# Altium schematic sheet LogicCircuits.SchDoc
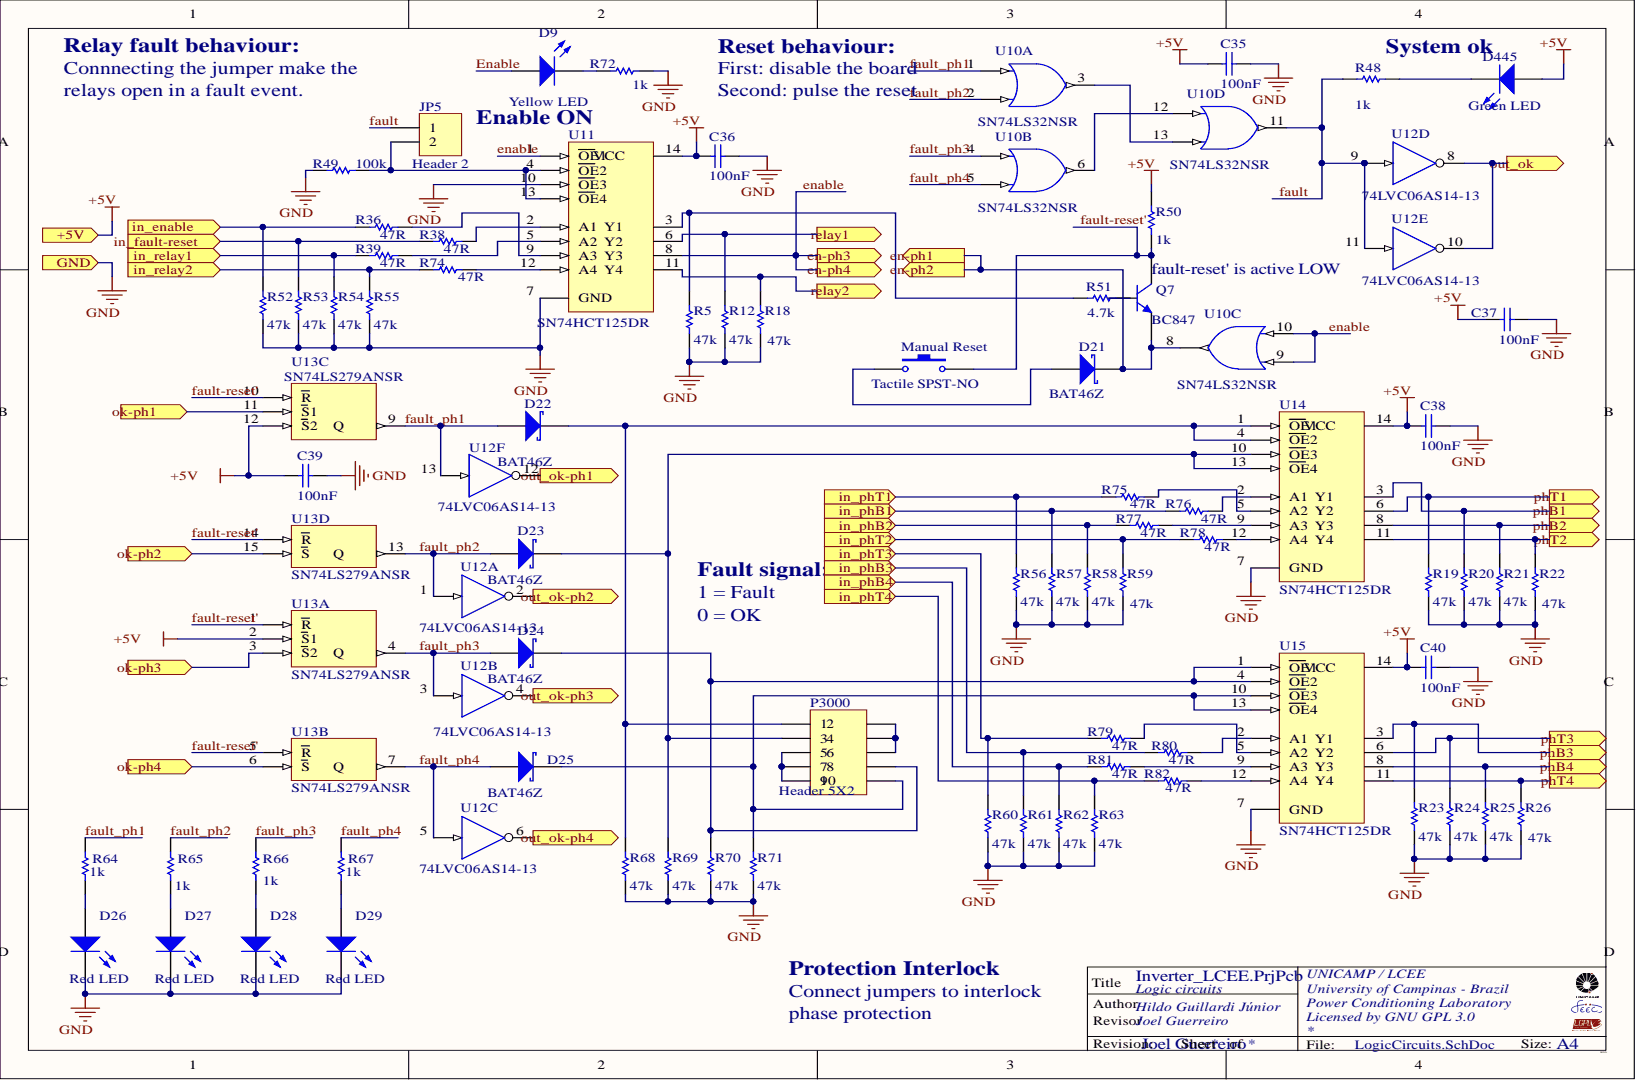
[diagram p0: LogicCircuits.SchDoc]

## Parts
C35  value 100nF  [0805_W]
C36  value 100nF  [0805_W]
C37  value 100nF  [0805_W]
C38  value 100nF  [0805_W]
C39  value 100nF  [0805_W]
C40  value 100nF  [0805_W]
D9  value Yellow LED  [LED_0805]
D21  value BAT46Z  [SOD-123]
D22  value BAT46Z  [SOD-123]
D23  value BAT46Z  [SOD-123]
D24  value BAT46Z  [SOD-123]
D25  value BAT46Z  [SOD-123]
D26  value Red LED  [LED_0805]
D27  value Red LED  [LED_0805]
D28  value Red LED  [LED_0805]
D29  value Red LED  [LED_0805]
D445  value Green LED  [LED_0805]
JP5  value Header 2  [HDR1X2]
Manual_Reset  value Tactile SPST-NO  [Tactile SPST-NO]
P3000  value Header 5X2  [HDR2X5]
Q7  value BC847  [SOT-23-TT3_N]
R5  value 47k  [0805_W]
R12  value 47k  [0805_W]
R18  value 47k  [0805_W]
R19  value 47k  [0805_W]
R20  value 47k  [0805_W]
R21  value 47k  [0805_W]
R22  value 47k  [0805_W]
R23  value 47k  [0805_W]
R24  value 47k  [0805_W]
R25  value 47k  [0805_W]
R26  value 47k  [0805_W]
R36  value 47R  [0805_W]
R38  value 47R  [0805_W]
R39  value 47R  [0805_W]
R48  value 1k  [0805_W]
R49  value 100k  [0805_W]
R50  value 1k  [0805_W]
R51  value 4.7k  [0805_W]
R52  value 47k  [0805_W]
R53  value 47k  [0805_W]
R54  value 47k  [0805_W]
R55  value 47k  [0805_W]
R56  value 47k  [0805_W]
R57  value 47k  [0805_W]
R58  value 47k  [0805_W]
R59  value 47k  [0805_W]
R60  value 47k  [0805_W]
R61  value 47k  [0805_W]
R62  value 47k  [0805_W]
R63  value 47k  [0805_W]
R64  value 1k  [0805_W]
R65  value 1k  [0805_W]
R66  value 1k  [0805_W]
R67  value 1k  [0805_W]
R68  value 47k  [0805_W]
R69  value 47k  [0805_W]
R70  value 47k  [0805_W]
R71  value 47k  [0805_W]
R72  value 1k  [0805_W]
R74  value 47R  [0805_W]
R75  value 47R  [0805_W]
R76  value 47R  [0805_W]
R77  value 47R  [0805_W]
R78  value 47R  [0805_W]
R79  value 47R  [0805_W]
R80  value 47R  [0805_W]
R81  value 47R  [0805_W]
R82  value 47R  [0805_W]
U10  value SN74LS32NSR  [SO14-Wide]  (parts A,B,C,D)
U11  value SN74HCT125DR  [SO14-Wide]
U12  value 74LVC06AS14-13  [SOIC-14N]  (parts A,B,C,D,E,F)
U13  value SN74LS279ANSR  (parts A,B,C,D)
U14  value SN74HCT125DR  [SO14-Wide]
U15  value SN74HCT125DR  [SO14-Wide]

## Ports
+5V, EN-PH1, EN-PH2, EN-PH3, EN-PH4, GND, IN_ENABLE, IN_FAULT-RESET, IN_PHB1, IN_PHB2, IN_PHB3, IN_PHB4, IN_PHT1, IN_PHT2, IN_PHT3, IN_PHT4, IN_RELAY1, IN_RELAY2, OK-PH1, OK-PH2, OK-PH3, OK-PH4, OUT_OK, OUT_OK-PH1, OUT_OK-PH2, OUT_OK-PH3, OUT_OK-PH4, PHB1, PHB2, PHB3, PHB4, PHT1, PHT2, PHT3, PHT4, RELAY1, RELAY2

## Nets
+5V: C35.1 C36.1 C37.1 C38.1 C39.1 C40.1 D445.1 R50.2 U10.14 U11.14 U12.14 U13.2(S1) U13.12(S2) U13.16 U14.14 U15.14 ; port +5V
ENABLE: D9.1 U10.9 U10.10 U11.1(OE1) U11.8 ; port EN-PH3, port EN-PH4
FAULT: JP5.1 R48.1 U10.11 U12.9 U12.11
FAULT-RESET': Manual_Reset.1 Q7.3 R50.1 U13.1(R) U13.5(R) U13.10(R) U13.14(R)
FAULT_PH1: D22.1 R64.2 U10.1 U12.13 U13.9
FAULT_PH2: D23.1 R65.2 U10.2 U12.1 U13.13
FAULT_PH3: D24.1 R66.2 U10.4 U12.3 U13.4
FAULT_PH4: D25.1 R67.2 U10.5 U12.5 U13.7
GND: C35.2 C36.2 C37.2 C38.2 C39.2 C40.2 D26.2 D27.2 D28.2 D29.2 R5.1 R12.1 R18.1 R19.1 R20.1 R21.1 R22.1 R23.1 R24.1 R25.1 R26.1 R49.2 R52.1 R53.1 R54.1 R55.1 R56.1 R57.1 R58.1 R59.1 R60.1 R61.1 R62.1 R63.1 R68.1 R69.1 R70.1 R71.1 R72.2 U10.7 U11.7(GND) U11.10(OE3) U12.7 U13.8 U14.7(GND) U15.7(GND) ; port GND
NetD9_2: D9.2 R72.1
NetD21_1: D21.1 Manual_Reset.2
NetD21_2: D21.2 Q7.2 U10.8 ; port EN-PH1, port EN-PH2
NetD22_2: D22.2 P3000.1 R68.2 U14.1(OE1) U14.4(OE2)
NetD23_2: D23.2 P3000.3 R69.2 U14.10(OE3) U14.13(OE4)
NetD24_2: D24.2 P3000.8 R70.2 U15.1(OE1) U15.4(OE2)
NetD25_2: D25.2 P3000.10 R71.2 U15.10(OE3) U15.13(OE4)
NetD26_1: D26.1 R64.1
NetD27_1: D27.1 R65.1
NetD28_1: D28.1 R66.1
NetD29_1: D29.1 R67.1
NetD445_2: D445.2 R48.2
NetJP5_2: JP5.2 R49.1 U11.4(OE2) U11.13(OE4)
NetP3000_2: P3000.2 P3000.4 P3000.6
NetP3000_5: P3000.5 P3000.7 P3000.9
NetQ7_1: Q7.1 R51.2
NetR5_2: R5.2 R51.1 U11.3
NetR12_2: R12.2 U11.6 ; port RELAY1
NetR18_2: R18.2 U11.11 ; port RELAY2
NetR19_2: R19.2 U14.6 ; port PHT1
NetR20_2: R20.2 U14.3 ; port PHB1
NetR21_2: R21.2 U14.8 ; port PHB2
NetR22_2: R22.2 U14.11 ; port PHT2
NetR23_2: R23.2 U15.3 ; port PHB3
NetR24_2: R24.2 U15.6 ; port PHT3
NetR25_2: R25.2 U15.8 ; port PHB4
NetR26_2: R26.2 U15.11 ; port PHT4
NetR36_1: R36.1 U11.9(A3)
NetR36_2: R36.2 R52.2 ; port IN_ENABLE
NetR38_1: R38.1 U11.2(A1)
NetR38_2: R38.2 R53.2 ; port IN_FAULT-RESET
NetR39_1: R39.1 U11.5(A2)
NetR39_2: R39.2 R54.2 ; port IN_RELAY1
NetR55_2: R55.2 R74.2 ; port IN_RELAY2
NetR56_2: R56.2 R75.2 ; port IN_PHT1
NetR57_2: R57.2 R76.2 ; port IN_PHB1
NetR58_2: R58.2 R77.2 ; port IN_PHB2
NetR59_2: R59.2 R78.2 ; port IN_PHT2
NetR60_2: R60.2 R79.2 ; port IN_PHT3
NetR61_2: R61.2 R80.2 ; port IN_PHB3
NetR62_2: R62.2 R81.2 ; port IN_PHB4
NetR63_2: R63.2 R82.2 ; port IN_PHT4
NetR74_1: R74.1 U11.12(A4)
NetR75_1: R75.1 U14.5(A2)
NetR76_1: R76.1 U14.2(A1)
NetR77_1: R77.1 U14.9(A3)
NetR78_1: R78.1 U14.12(A4)
NetR79_1: R79.1 U15.5(A2)
NetR80_1: R80.1 U15.2(A1)
NetR81_1: R81.1 U15.9(A3)
NetR82_1: R82.1 U15.12(A4)
NetU10_3: U10.3 U10.13
NetU10_6: U10.6 U10.12
NetU12_2: U12.2 ; port OUT_OK-PH2
NetU12_4: U12.4 ; port OUT_OK-PH3
NetU12_6: U12.6 ; port OUT_OK-PH4
NetU12_8: U12.8 U12.10 ; port OUT_OK
NetU12_12: U12.12 ; port OUT_OK-PH1
NetU13_3: U13.3(S2) ; port OK-PH3
NetU13_6: U13.6(S) ; port OK-PH4
NetU13_11: U13.11(S1) ; port OK-PH1
NetU13_15: U13.15(S) ; port OK-PH2

## Library data of the parts
C35-C40 = Capacitor_nao_polarizado: Capacitor nao polarizado; Component Kind: Standard; Value: Value; Library Name: Sch_LCEE.SchLib
D9 D26-D29 D445 = LED0: Typical INFRARED GaAs LED; Component Kind: Standard; LatestRevisionDate: 08-Jul-2005; LatestRevisionNote: Mech Layer 1 removed.; Library Name: Miscellaneous Devices.IntLib; PackageDescription: LED; 2 Leads; PackageReference: LED-0; Published: 8-Jun-2000; Simulation: LED0; Publisher: Altium Limited
D21 D25 = D Schottky: Schottky Diode; Code_JEDEC: DO-214-AA; Component Kind: Standard; LatestRevisionDate: 17-Jul-2002; LatestRevisionNote: Re-released for DXP Platform.; Library Name: Miscellaneous Devices.IntLib; PackageDescription: DO-214-AA/SMB; 2 C-Bend Leads; Body 5.3 x 3.6 mm, inc. leads (LxW); PackageReference: SMB; Published: 8-Jun-2000; Signal Integrity: D Schottky; Simulation: SKYDIODE; Publisher: Altium Limited
D22-D24 = D Schottky: Schottky Diode; Value: BAT46Z; Code_JEDEC: DO-214-AA; Component Kind: Standard; LatestRevisionDate: 17-Jul-2002; LatestRevisionNote: Re-released for DXP Platform.; Library Name: Miscellaneous Devices.IntLib; PackageDescription: DO-214-AA/SMB; 2 C-Bend Leads; Body 5.3 x 3.6 mm, inc. leads (LxW); PackageReference: SMB; Published: 8-Jun-2000; Signal Integrity: D Schottky; Simulation: SKYDIODE; Publisher: Altium Limited
JP5 = Header 2: Header, 2-Pin; Manufacturer: Generic Components; Class: Connector; Component Kind: Standard; Signal Integrity: Connector; Library Name: AQAC.SCHLIB
Manual_Reset = SW-PB: Switch; Component Kind: Standard; LatestRevisionDate: 17-Jul-2002; LatestRevisionNote: Re-released for DXP Platform.; Library Name: Miscellaneous Devices.IntLib; PackageDescription: Switch; 2 Leads; PackageReference: SPST-2; Published: 24-Mar-1999; Publisher: Altium Limited
P3000 = Header 5X2: Header, 5-Pin, Dual row; Component Kind: Standard; LatestRevisionDate: 17-Jul-2002; LatestRevisionNote: Re-released for DXP Platform.; Library Name: Miscellaneous Connectors.IntLib; Signal Integrity: Connector; Publisher: Altium Limited
Q7 = BC847: NPN General-purpose Transistor; Component Kind: Standard; ComponentLink1Description: Manufacturer Link; ComponentLink1URL: http://www.semiconductors.philips.com/; ComponentLink2Description: Datasheet; ComponentLink2URL: http://www.semiconductors.philips.com/acrobat/datasheets/BC846_BC847_BC848_5.pdf; DatasheetVersion: 4-Feb-2002; LatestRevisionDate: 12-Dec-2006; LatestRevisionNote: IPC-7351 Footprint Added.; Library Name: Philips Discrete BJT - Low Power.IntLib; PackageDescription: 3-Pin SOT-23 Package 0.95 mm Pitch, 2.3 mm Lead Span; PackageReference: SOT23; PackageVersion: May-1999; Published: 17-Sep-2003; Signal Integrity: BC847; Simulation: QBC847; Publisher: Altium Limited
R5 R12 R18-R26 R36 R38 R39 R48-R50 R52-R72 R74-R82 = Res_0805: Resistor; Manufacturer: Generic Components; Class: Passive; Component Kind: Standard; Published: 08/06/2000; Signal Integrity: Res1; Simulation: RESISTOR; SubClass: Resistor; Value: Value; Library Name: Inversor_LCEE.SchLib; Publisher: Altium Hobart Technology Centre
R51 = Res_0805: Resistor; Manufacturer: Generic Components; Class: Passive; Value: 4.7k; Component Kind: Standard; Published: 08/06/2000; Signal Integrity: Res1; Simulation: RESISTOR; SubClass: Resistor; Value: Value; Library Name: Inversor_LCEE.SchLib; Publisher: Altium Hobart Technology Centre
U10 = HD74HC32FP: Quad 2-Input OR Gates; Code_JEITA: P-SOP14-5.5x10.06-1.27; Component Kind: Standard; ComponentLink1Description: Manufacturer Link; ComponentLink1URL: http://sg.renesas.com/fmwk.jsp?cnt=hd74hc_root.jsp&fp=/products/standard_ic/general_purpose_logic/5v_standard_logic/hd74hc/; ComponentLink2Description: Datasheet; ComponentLink2URL: http://documentation.renesas.com/eng/products/logic/rej03d0545_hd74hc32ds.pdf; ComponentLink3Description: Packaging Specification; ComponentLink3URL: http://sg.renesas.com/media/products/common_info/package/pdf/ic/code/prsp0014df_b.pdf; DatasheetVersion: Rev.2.00, Oct-2005; Library Name: Renesas Logic.IntLib; PackageDescription: 14-Pin Plastic Small Outline Package, 10.06 x 5.5 mm Body, 1.27 mm Pitch; PackageReference: PRSP0014DF-B; PackageVersion: Sep-2005; Published: 10-Feb-2010; Publisher: Altium Limited
U11 U14 U15 = M74HC125M1R: Quad Bus Buffer (3-State); Code_IPC: SOIC127P600-14; Component Kind: Standard; ComponentLink1Description: Manufacturer Link; ComponentLink1URL: http://www.st.com/; ComponentLink2Description: Datasheet; ComponentLink2URL: http://www.st.com/stonline/products/literature/ds/1894/m74hc125.pdf; DatasheetVersion: Sep-1993; LatestRevisionDate: 13-Apr-2006; LatestRevisionNote: IPC-7351 Footprint Added.; Library Name: ST Logic Buffer Line Driver.IntLib; PackageDescription: 14-Pin Small Outline Integrated Circuit 1.27 mm Pitch; PackageReference: SO14; PackageVersion: Sep-1998; PCB3D: SO14; Published: 8-Jun-2000; Signal Integrity: M74HC125M1R; Publisher: Altium Limited
U12 = HD74LV06AFP: Hex Inverter Buffers / Drivers with Open Drain Outputs; Code_JEITA: P-SOP14-5.5x10.06-1.27; Component Kind: Standard; ComponentLink1Description: Manufacturer Link; ComponentLink1URL: http://sg.renesas.com/fmwk.jsp?cnt=hd74lv_a_root.jsp&fp=/products/standard_ic/general_purpose_logic/low_voltage_logic/hd74lv_a/; ComponentLink2Description: Datasheet; ComponentLink2URL: http://documentation.renesas.com/eng/products/logic/rej03d0230_hd74lv06a.pdf; ComponentLink3Description: Packaging Specification; ComponentLink3URL: http://sg.renesas.com/media/products/common_info/package/pdf/ic/code/prsp0014df_b.pdf; DatasheetVersion: Rev.6.00, Dec-2005; Library Name: Renesas Logic.IntLib; PackageDescription: 14-Pin Plastic Small Outline Package, 10.06 x 5.5 mm Body, 1.27 mm Pitch; PackageReference: PRSP0014DF-B; PackageVersion: Sep-2005; Published: 10-Feb-2010; Publisher: Altium Limited
U13 = SN74LS279AD: Quadruple S-R Latches; Code_IPC: SOIC127P600-16; Component Kind: Standard; ComponentLink1Description: Manufacturer Link; ComponentLink1URL: http://www.ti.com/; ComponentLink2Description: Datasheet; ComponentLink2URL: http://www-s.ti.com/sc/ds/sn54279.pdf; DatasheetVersion: Mar-1988; LatestRevisionDate: 13-Apr-2006; LatestRevisionNote: IPC-7351 Footprint Added.; Library Name: TI Logic Latch.IntLib; PackageDescription: 16-Pin Small Outline Integrated Circuit 1.27 mm Pitch; PackageReference: D016; PackageVersion: Jan-1998; PCB3D: D016; Published: 8-Jun-2000; Signal Integrity: SN74LS279AD; Publisher: Altium Limited
